# Altium schematic sheet Placa CAN.SchDoc
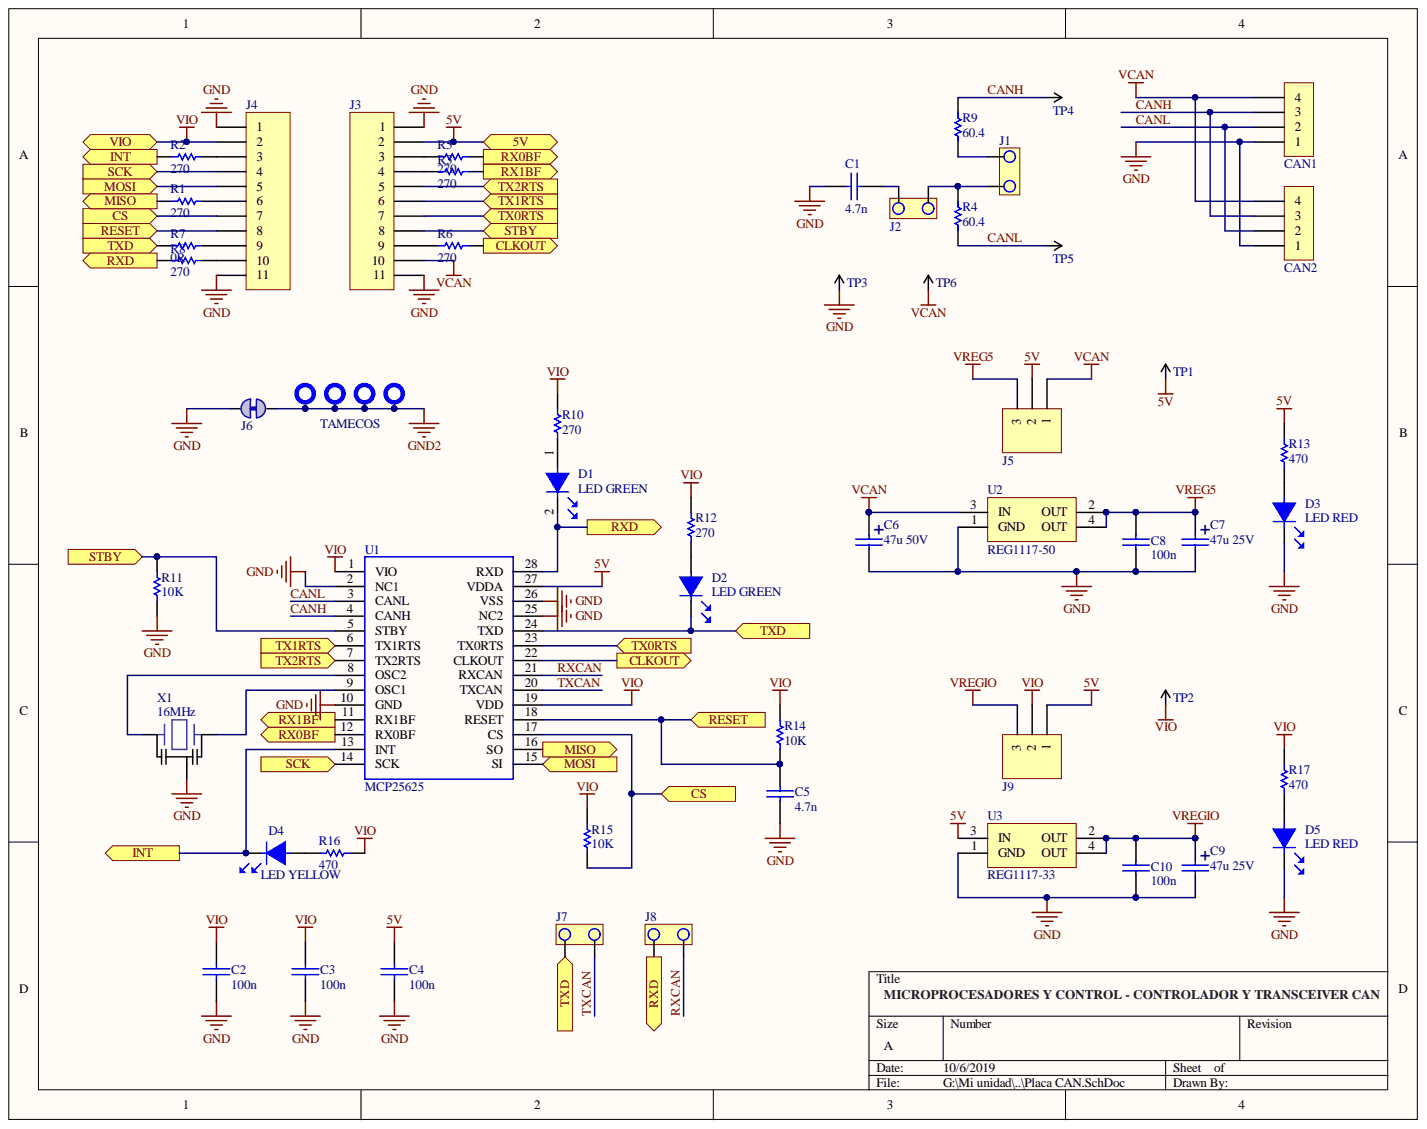
[diagram p0: Placa CAN.SchDoc]

## Parts
C1  4.7n  [CAPSMD0805]
C2  100n  [CAPSMD0805]
C3  100n  [CAPSMD0805]
C4  100n  [CAPSMD0805]
C5  4.7n  [CAPSMD0805]
C6  47u 50V  [CAP100RP2]
C7  47u 25V  [CAP100RP2]
C8  100n  [CAPSMD0805]
C9  47u 25V  [CAP100RP2]
C10  100n  [CAPSMD0805]
CAN1  Header Polarized 4  [MOLEX4]  (value not drawn)
CAN2  Header Polarized 4  [MOLEX4]  (value not drawn)
D1  LED GREEN  [LEDSMD0805]
D2  LED GREEN  [LEDSMD0805]
D3  LED RED  [LEDSMD0805]
D4  LED YELLOW  [LEDSMD0805]
D5  LED RED  [LEDSMD0805]
J1  Connector 2 + Jumper  [JUMPER2]  (value not drawn)
J2  Connector 2 + Jumper  [JUMPER2]  (value not drawn)
J3  Header 11  [SIP11]  (value not drawn)
J4  Header 11  [SIP11]  (value not drawn)
J5  Connector 3 + Jumper  [JUMPER3]  (value not drawn)
J6  Solderbridge-ShortDNP  [Solderbridge-Short]  (value not drawn)
J7  Connector 2 + Jumper  [JUMPER2]  (value not drawn)
J8  Connector 2 + Jumper  [JUMPER2]  (value not drawn)
J9  Connector 3 + Jumper  [JUMPER3]  (value not drawn)
J10  Tameco  [TAMECO_HOLE]  (value not drawn)
J11  Tameco  [TAMECO_HOLE]  (value not drawn)
J12  Tameco  [TAMECO_HOLE]  (value not drawn)
J13  Tameco  [TAMECO_HOLE]  (value not drawn)
R1  270  [RESSMD0805]
R2  270  [RESSMD0805]
R3  270  [RESSMD0805]
R4  60.4  [RESSMD0805]
R5  270  [RESSMD0805]
R6  270  [RESSMD0805]
R7  0R  [RESSMD0805]
R8  270  [RESSMD0805]
R9  60.4  [RESSMD0805]
R10  270  [RESSMD0805]
R11  10K  [RESSMD0805]
R12  270  [RESSMD0805]
R13  470  [RESSMD0805]
R14  10K  [RESSMD0805]
R15  10K  [RESSMD0805]
R16  470  [RESSMD0805]
R17  470  [RESSMD0805]
TP1  TESTPOINT  [TP_SMD_Ø1.0mm]  (value not drawn)  (no BOM)
TP2  TESTPOINT  [TP_SMD_Ø1.0mm]  (value not drawn)  (no BOM)
TP3  TESTPOINT  [TP_SMD_Ø1.0mm]  (value not drawn)  (no BOM)
TP4  TESTPOINT  [TP_SMD_Ø1.0mm]  (value not drawn)  (no BOM)
TP5  TESTPOINT  [TP_SMD_Ø1.0mm]  (value not drawn)  (no BOM)
TP6  TESTPOINT  [TP_SMD_Ø1.0mm]  (value not drawn)  (no BOM)
U1  MCP25625  [SOP65P780X200-28N]
U2  REG1117-50  [SOT-223]
U3  REG1117-33  [SOT-223]
X1  16MHz  [CSTNE]

## Ports
5V, CLKOUT, CS, INT, MISO, MOSI, RESET, RX0BF, RX1BF, RXD, SCK, STBY, TX0RTS, TX1RTS, TX2RTS, TXD, VIO

## Nets
GND: C1.1 C2.1 C3.2 C4.1 C5.1 C6.2 C7.2 C8.1 C9.2 C10.1 CAN1.1 CAN2.1 D3.2 D5.2 J3.1 J3.11 J4.1 J4.11 J6.2 R11.1 TP3.1 U1.2(NC1) U1.10(GND) U1.25(NC2) U1.26(VSS) U2.1(GND) U3.1(GND) X1.2
GND2: J6.1 J10.1 J11.1 J12.1 J13.1
VCAN: C6.1 CAN1.4 CAN2.4 J3.10 J5.1 TP6.1 U2.3(IN)
VREG5: C7.1 C8.2 J5.3 U2.2(OUT) U2.4(OUT)
VREGIO: C9.1 C10.2 J9.3 U3.2(OUT) U3.4(OUT)
5V: C4.2 J3.2 J5.2 J9.1 R13.2 TP1.1 U1.27(VDDA) U3.3(IN) ; port 5V
CANH: CAN1.3 CAN2.3 R9.1 TP4.1 U1.4(CANH)
CANL: CAN1.2 CAN2.2 R4.2 TP5.1 U1.3(CANL)
CLKOUT: R6.2 U1.22(CLKOUT) ; port CLKOUT
CS: J4.7 R15.1 U1.17(CS) ; port CS
INT: D4.2 R2.1 U1.13(INT) ; port INT
MISO: R1.1 U1.16(SO) ; port MISO
MOSI: J4.5 U1.15(SI) ; port MOSI
RESET: C5.2 J4.8 R14.1 U1.18(RESET) ; port RESET
RX0BF: R5.1 U1.12(RX0BF) ; port RX0BF
RX1BF: R3.2 U1.11(RX1BF) ; port RX1BF
RXCAN: J8.1 U1.21(RXCAN)
RXD: D1.2 J8.2 R8.1 U1.28(RXD) ; port RXD
SCK: J4.4 U1.14(SCK) ; port SCK
STBY: J3.8 R11.2 U1.5(STBY) ; port STBY
TX0RTS: J3.7 U1.23(TX0RTS) ; port TX0RTS
TX1RTS: J3.6 U1.6(TX1RTS) ; port TX1RTS
TX2RTS: J3.5 U1.7(TX2RTS) ; port TX2RTS
TXCAN: J7.1 U1.20(TXCAN)
TXD: D2.2 J7.2 R7.2 U1.24(TXD) ; port TXD
VIO: C2.2 C3.1 J4.2 J9.2 R10.2 R12.2 R14.2 R15.2 R16.2 R17.2 TP2.1 U1.1(VIO) U1.19(VDD) ; port VIO
NetC1_2: C1.2 J2.1
NetD1_1: D1.1 R10.1
NetD2_1: D2.1 R12.1
NetD3_1: D3.1 R13.1
NetD4_1: D4.1 R16.1
NetD5_1: D5.1 R17.1
NetJ1_1: J1.1 J2.2 R4.1
NetJ1_2: J1.2 R9.2
NetJ3_3: J3.3 R5.2
NetJ3_4: J3.4 R3.1
NetJ3_9: J3.9 R6.1
NetJ4_3: J4.3 R2.2
NetJ4_6: J4.6 R1.2
NetJ4_9: J4.9 R7.1
NetJ4_10: J4.10 R8.2
NetU1_8: U1.8(OSC2) X1.1
NetU1_9: U1.9(OSC1) X1.3

## Library data of the parts
C1 C5 = CAP: Capacitor SMD 4.7nF; Component Kind: Standard; Library Name: LIBEBAL.IntLib
C2-C4 C8 C10 = CAP: Capacitor SMD 100nF; Component Kind: Standard; Library Name: LIBEBAL.IntLib
C6 C7 C9 = CAPPOL: Capacitor Polarized; Component Kind: Standard; Library Name: LIBEBAL.IntLib
CAN1 CAN2 = CON4: Component Kind: Standard; Description: Header Polarized 4; Library Name: LIBEBAL.IntLib
D1 D2 = LED: LED SMD GREEN; Component Kind: Standard; Library Name: LIBEBAL.IntLib
D3 D5 = LED: LED SMD RED; Component Kind: Standard; Library Name: LIBEBAL.IntLib
D4 = LED: LED SMD YELLOW; Component Kind: Standard; Library Name: LIBEBAL.IntLib
J1 J2 J7 J8 = JUMPER: Component Kind: Standard; Description: Connector 2 + Jumper; Library Name: Placa CAN.SchLib
J5 J9 = Header 3: Component Kind: Standard; Description: Connector 3 + Jumper; LatestRevisionDate: 17-Jul-2002; LatestRevisionNote: Re-released for DXP Platform.; Library Name: Miscellaneous Connectors.IntLib; Signal Integrity: Connector; Publisher: Altium Limited
J6 = Solderbridge-Short: Added By: Paul B; Added Date: 12/2/2015; Component Kind: Net Tie; Description: Solderbridge-Short; DNP: DNP; Height: 0; Part Number: Solderbridge-Short; Library Name: Placa CAN.SchLib
R1-R3 R5 R6 R8 R10 R12 = RES: Resistencia SMD 270ohms; Component Kind: Standard; Library Name: Placa Can.SchLib
R4 R9 = RES: Resistencia SMD 60.4ohms; Component Kind: Standard; Library Name: Placa Can.SchLib
R7 = RES: Resistencia SMD 0ohms; Component Kind: Standard; Library Name: Placa Can.SchLib
R11 R14 R15 = RES: Resistencia SMD 10kohms; Component Kind: Standard
  R11 R15: Library Name: Placa Can.SchLib
  R14: Library Name: Placa CAN.SchLib
R13 R16 R17 = RES: Resistencia SMD 470ohms; Component Kind: Standard; Library Name: Placa Can.SchLib
TP1-TP6 = TESTPOINT: 1.0mm circular SMD testpad; Manufacturer: Nordic Semiconductor; Value: Ø1.0mm,SMD; Library Name: Placa CAN.SchLib
U1 = MCP25625-E_SS: CAN Controller with Transceiver SSOP28 Microchip MCP25625-E/SS, CAN Controller 1MBps CAN 2.0, 28-Pin SSOP; PartNumber: MCP25625T-E/SS; Allied_Number: 70415903; Geometry.Height: 2mm; Allied Price/Stock: https://www.alliedelec.com/microchip-technology-inc-mcp25625-e-ss/70415903/; Arrow Part Number: MCP25625-E/SS; Arrow Price/Stock: https://www.arrow.com/en/products/mcp25625-ess/microchip-technology; Component Kind: Standard; Datasheet Link: http://ww1.microchip.com/downloads/en/DeviceDoc/20005282B.pdf; Manufacturer_Name: Microchip; Manufacturer_Part_Number: MCP25625-E/SS; RS Part Number: 1651935; RS Price/Stock: http://uk.rs-online.com/web/p/products/1651935; Library Name: Placa CAN.SchLib
U2 = REG1117-5: Regulator LDO 5V; PartNumber: TLV1117-50CDCYR; Component Kind: Standard; ComponentLink1Description: Manufacturer Link; ComponentLink1URL: http://www.ti.com/; ComponentLink2Description: Datasheet; ComponentLink2URL: http://www-s.ti.com/sc/ds/REG1117.pdf; DatasheetVersion: Sep-1997; LatestRevisionDate: 17-Jul-2002; LatestRevisionNote: Re-released for DXP Platform.; PackageDescription: SOT-223/SC-73; 4 Leads; Body 6.7 x 7.3 mm, inc. leads (LxW max); PackageVersion: revC; Published: 8-Jun-2000; Library Name: PuenteH_L293D.SCHLIB; Publisher: Altium Limited
U3 = REG1117-5: Regulator LDO 3.3V; PartNumber: TLV1117-33CDCYR; Component Kind: Standard; ComponentLink1Description: Manufacturer Link; ComponentLink1URL: http://www.ti.com/; ComponentLink2Description: Datasheet; ComponentLink2URL: http://www-s.ti.com/sc/ds/REG1117.pdf; DatasheetVersion: Sep-1997; LatestRevisionDate: 17-Jul-2002; LatestRevisionNote: Re-released for DXP Platform.; PackageDescription: SOT-223/SC-73; 4 Leads; Body 6.7 x 7.3 mm, inc. leads (LxW max); PackageVersion: revC; Published: 8-Jun-2000; Library Name: PuenteH_L293D.SCHLIB; Publisher: Altium Limited
X1 = CSTCE16M0V53-R0: Cristal 16MHz; PartNumber: CSTNE16M0VH3L000R0; Component Kind: Standard; Library Name: murata.IntLib; Value: 16MHz
J3 J4 = Header 11: Component Kind: Standard; Description: Header 11; LatestRevisionDate: 17-Jul-2002; LatestRevisionNote: Re-released for DXP Platform.; Library Name: Miscellaneous Connectors.IntLib; Signal Integrity: Connector; Publisher: Altium Limited
J10-J13 = (no symbol name): Component Kind: Standard; Description: Tameco; Library Name: Placa CAN.SchLib
